# Altium schematic sheet czad.SchDoc(czad)
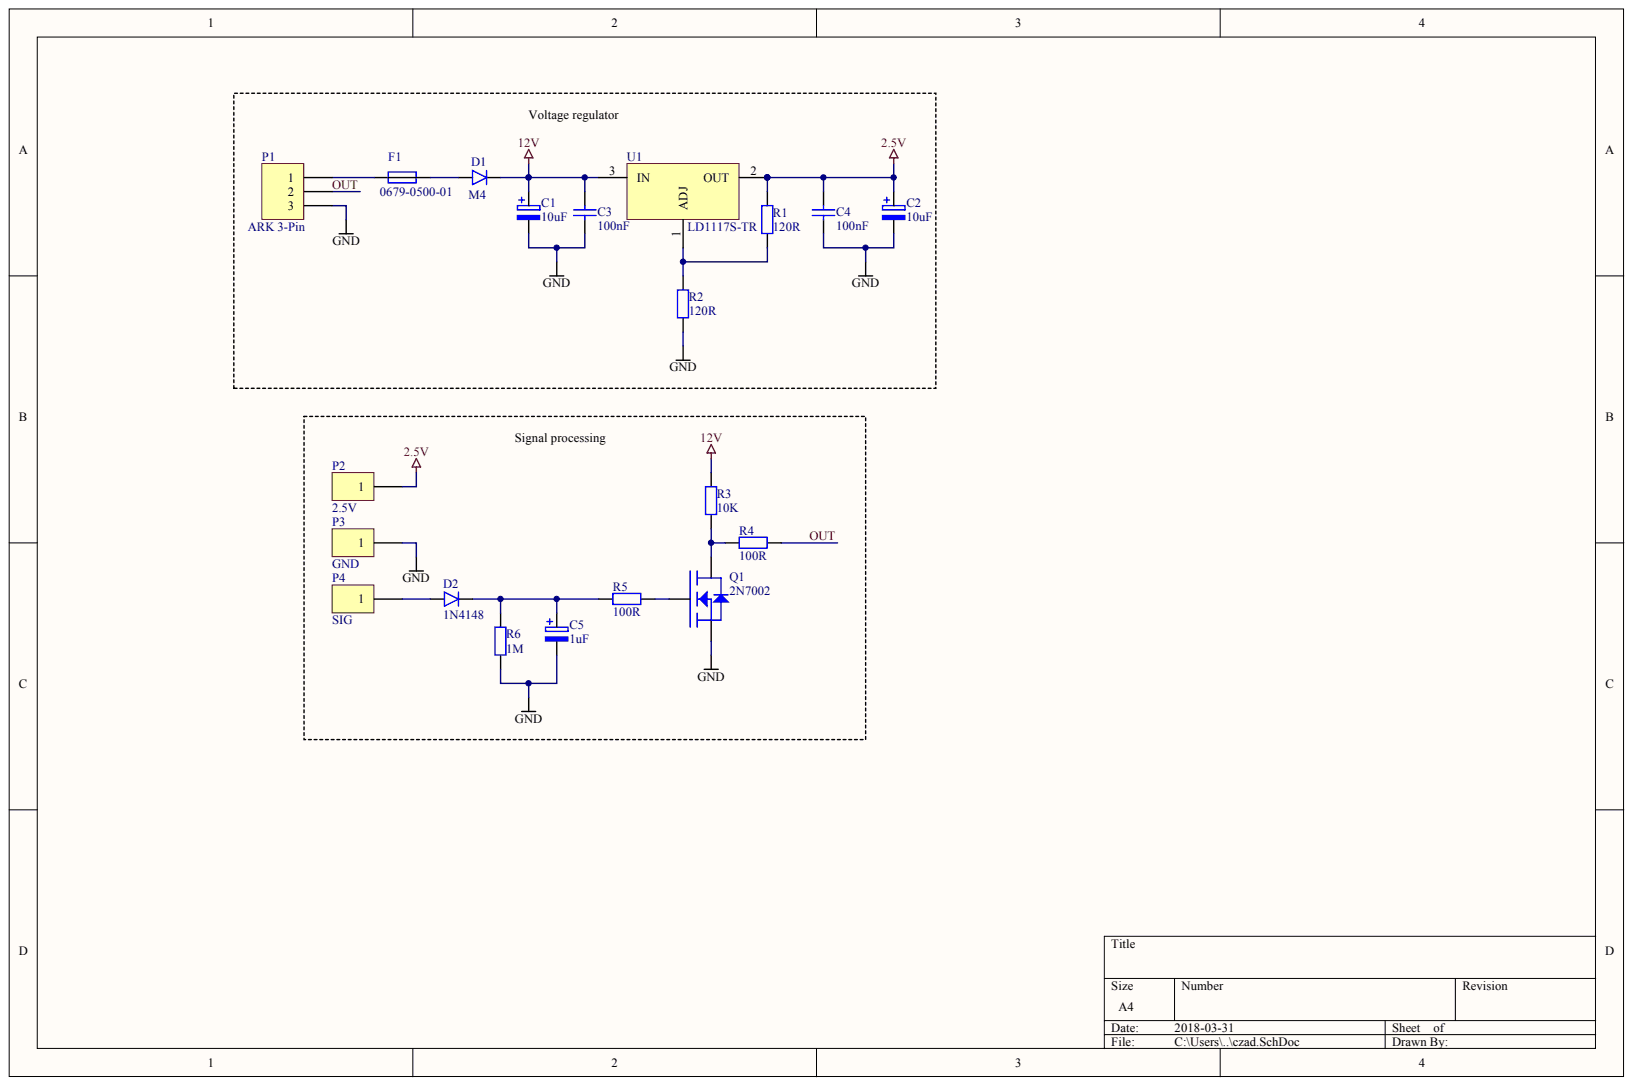
[diagram p0: czad.SchDoc]

## Parts
C1  10uF
C2  10uF
C3  100nF
C4  100nF
C5  1uF
D1  M4
D2  1N4148
F1  0679-0500-01
P1  ARK 3-Pin
P2  2.5V
P3  GND
P4  SIG
Q1  2N7002
R1  120R
R2  120R
R3  10K
R4  100R
R5  100R
R6  1M
U1  LD1117S-TR

## Nets
2.5V: C2.1 C4.1 P2.1 R1.1 U1.2
12V: C1.1 C3.1 D1.1 R3.1 U1.3
GND: C1.2 C2.2 C3.2 C4.2 C5.2 P1.3 P3.1 Q1.2 R2.2 R6.1
OUT: P1.2 R4.1
NetC5_1: C5.1 D2.1 R5.2 R6.2
NetD1_2: D1.2 F1.2
NetD2_2: D2.2 P4.1
NetF1_1: F1.1 P1.1
NetQ1_1: Q1.1 R5.1
NetQ1_3: Q1.3 R3.2 R4.2
NetR1_2: R1.2 R2.1 U1.1
